# Altium schematic sheet STM32WL_Sensors.SchDoc(STM32WL_Sensors)
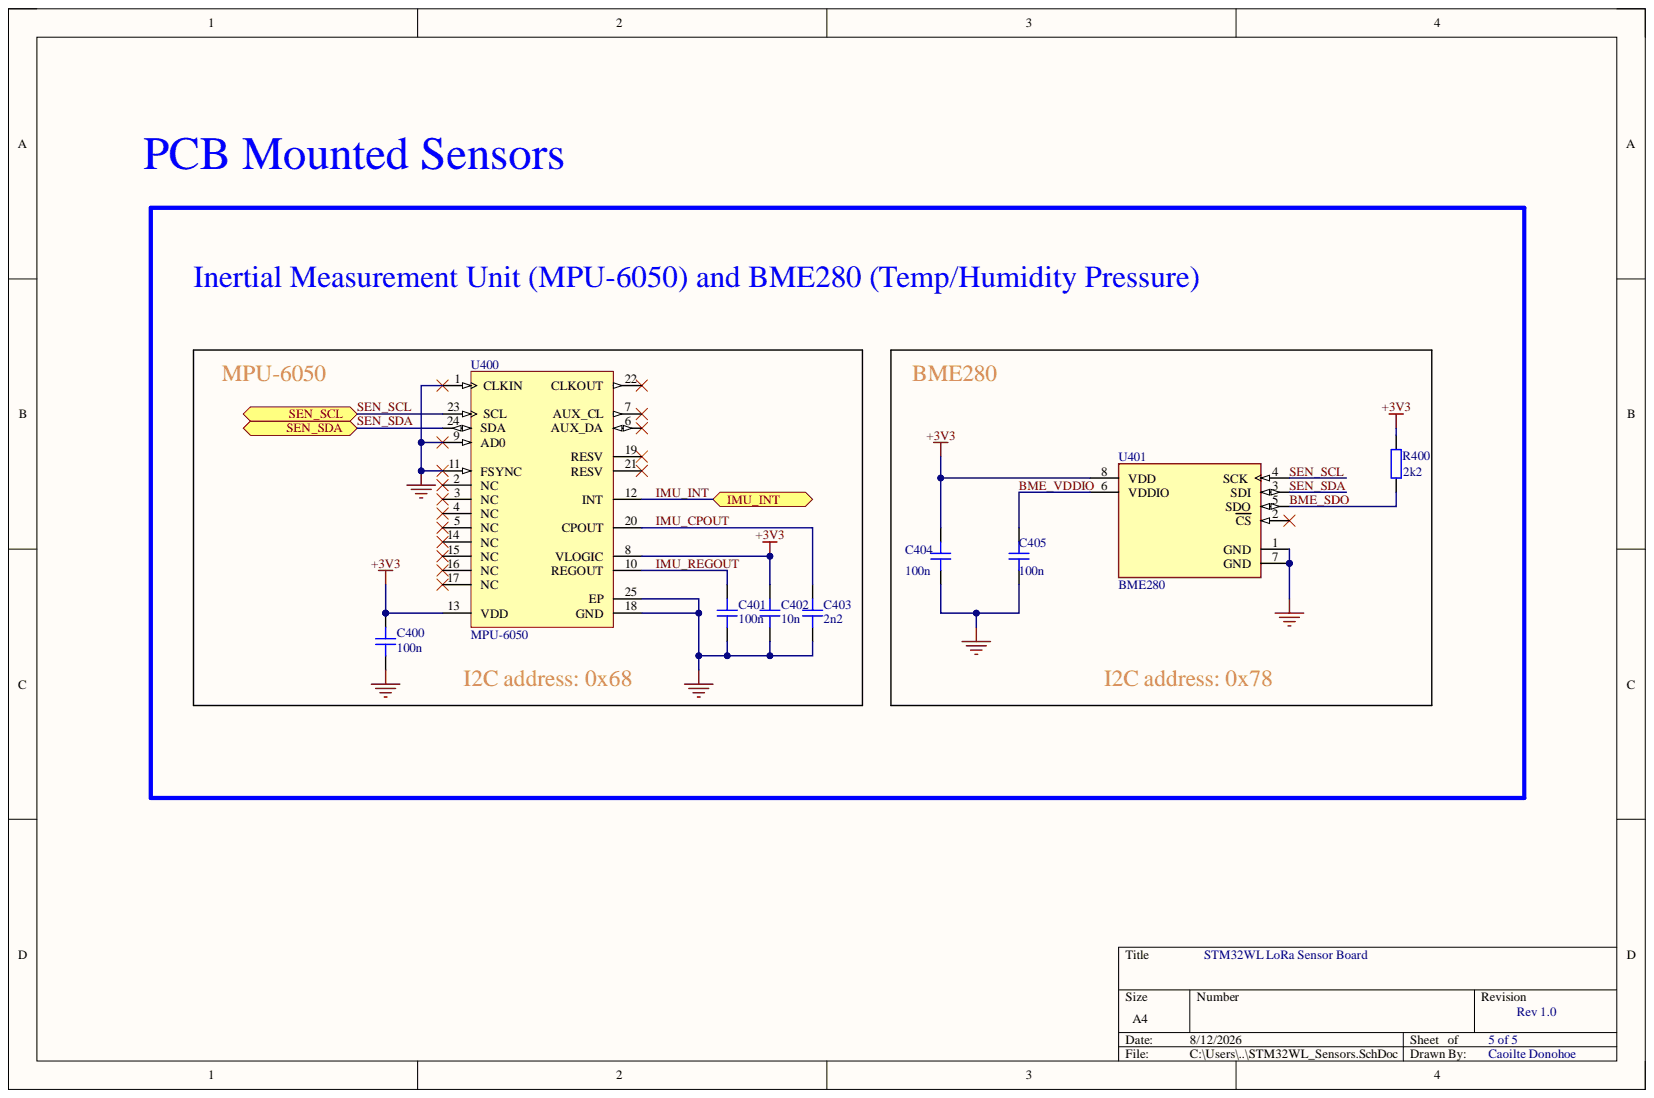
[diagram p0: STM32WL_Sensors.SchDoc]

## Parts
C400  100n  [CAP_0402]
C401  100n  [CAP_0402]
C402  10n  [CAP_0402]
C403  2n2  [CAP_0402]
C404  100n  [CAP_0402]
C405  100n  [CAP_0402]
R400  2k2  [RES_0402]
U400  MPU-6050  [IS-QFN-24_V]
U401  BME280  [FP-BME280-MFG]

## Ports
IMU_INT, SEN_SCL, SEN_SDA

## Nets
+3V3: C400.2 C402.2 C404.2 R400.2 U400.8(VLOGIC) U400.13(VDD) U401.8(VDD)
GND: C400.1 C401.1 C402.1 C403.1 C404.1 C405.1 U400.1(CLKIN) U400.9(AD0) U400.11(FSYNC) U400.18(GND) U400.25(EP) U401.1(GND) U401.7(GND)
BME_SDO: R400.1 U401.5(SDO)
BME_VDDIO: C405.2 U401.6(VDDIO)
IMU_CPOUT: C403.2 U400.20(CPOUT)
IMU_INT: U400.12(INT) ; port IMU_INT
IMU_REGOUT: C401.2 U400.10(REGOUT)
SEN_SCL: U400.23(SCL) U401.4(SCK) ; port SEN_SCL
SEN_SDA: U400.24(SDA) U401.3(SDI) ; port SEN_SDA

Not connected: U400 2(NC) 3(NC) 4(NC) 5(NC) 6(AUX_DA) 7(AUX_CL) 14(NC) 15(NC) 16(NC) 17(NC) 19(RESV) 21(RESV) 22(CLKOUT); U401 2(CS)

## Library data of the parts
C400-C405 = CAP_0402_16V: Capacitor SMD 0402 16V; Component Kind: Standard; Library Name: Schematic-Lib-PhilsLab.SchLib
R400 = RES_0402: Resistor SMD 0402; Component Kind: Standard; Library Name: Schematic-Lib-PhilsLab.SchLib
U400 = CMP-1753-00002-2: 3-Axis Gyroscope, Accelerometer and a Digital Motion Processor(TM) (DMP), 2.375 to 3.46 V, -40 to 85 degC, 24-Pin QFN, RoHS, Tape and Reel; Manufacturer: InvenSense; Dynamic Catalog: MPU-6000™Series; Featured Product: MPU-60506-Axis,IntegratedI²CSolution; Other Names: 1428-1007-2  MPU6050; Sensor Type: MotionTracking; Category: Sensors,Transducers; Component Kind: Standard; Datasheet URL: http://datasheet.octopart.com/MPU-6050-InvenSense-datasheet-14421344.pdf; Datasheet Version: Rev. 3.3, 05/2012; Family: Multifunction; Library Name: Altium Content Vault; Manufacturer URL: http://www.invensense.com/; Online Catalog: MPU-6000™Series; Output Type: Digital; Standard Package: 5,000; Unit PriceUSD: Digital
U401 = CMP-2000-05073-3: SENSOR PRESSURE HUMIDITY TEMP; Accuracy: 3%; Output Type: SPI; Response Time: 1s; Sensor Type: Temperature; Case/Package: LGA; Component Kind: Standard; Lead Free: Lead Free; Library Name: Altium Content Vault; Max Operating Temperature: 85°C; Min Operating Temperature: -40°C; Mount: Surface Mount; Number of Pins: 8; Packaging: Cut Tape
